# Altium schematic sheet N2K DB2 Interface.SchDoc
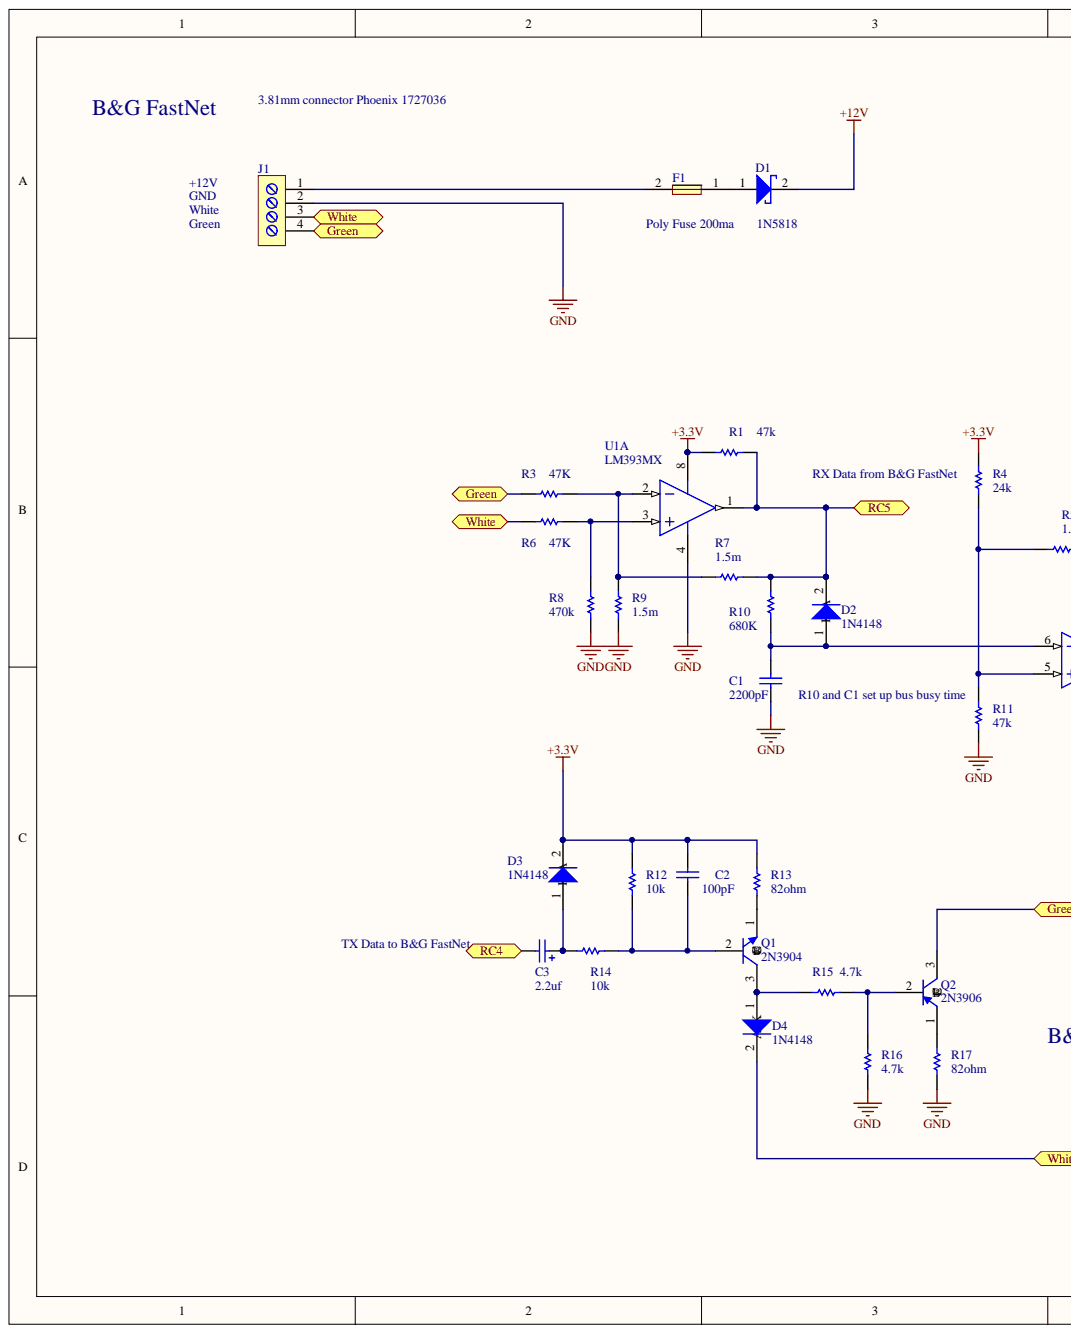
[diagram p0: N2K DB2 Interface.SchDoc, left]
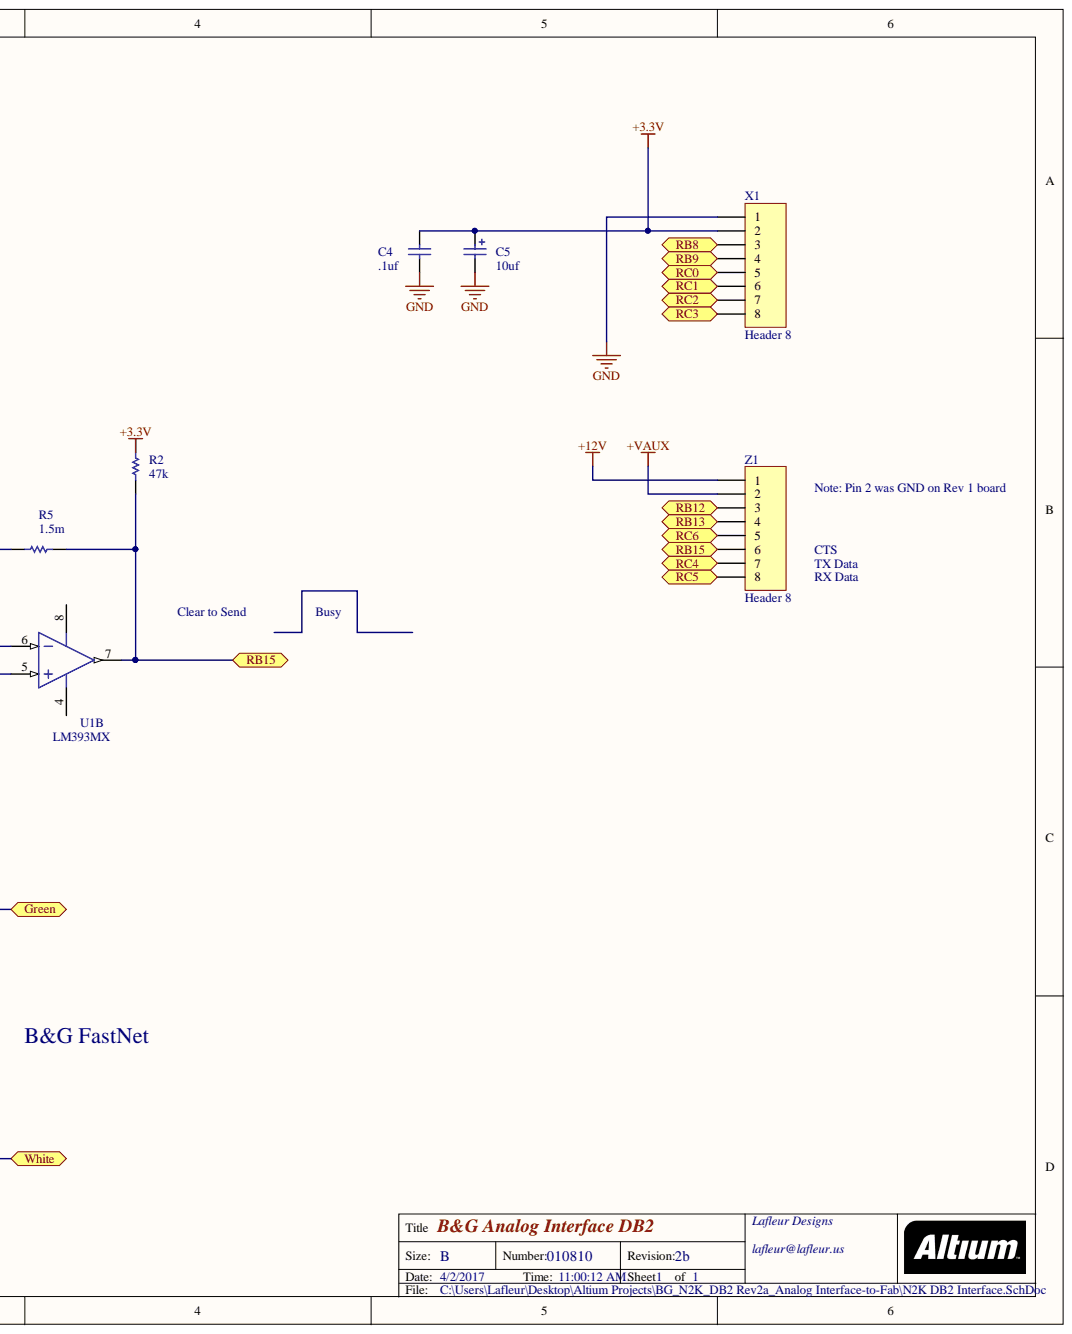
[diagram p1: N2K DB2 Interface.SchDoc, right]

## Parts
C1  value 2200pF  [0805]
C2  value 100pF  [0805]
C3  value 2.2uf  [CAPM3216X18N]
C4  value .1uf  [0805]
C5  value 10uf  [CAPM2528X18N]
D1  1N5818  [DO214]
D2  1N4148  [SOT23-3L]
D3  1N4148  [SOT23-3L]
D4  1N4148  [SOT23-3L]
F1  Poly Fuse 200ma  [PTC 2016L]
J1  (no value)  [TS3.81X4]
Q1  2N3904  [SOT23-3L]
Q2  2N3906  [SOT23-3N]
R1  47k  [6-0805]
R2  47k  [6-0805]
R3  47K  [6-0805]
R4  24k  [6-0805]
R5  1.5m  [6-0805]
R6  47K  [6-0805]
R7  1.5m  [6-0805]
R8  470k  [6-0805]
R9  1.5m  [6-0805]
R10  680K  [6-0805]
R11  47k  [6-0805]
R12  10k  [6-0805]
R13  82ohm  [8-1206]
R14  10k  [6-0805]
R15  4.7k  [6-0805]
R16  4.7k  [6-0805]
R17  82ohm  [8-1206]
U1  LM393MX  [SOIC-SN8_N]  (parts A,B)
X1  Header 8  [HDR1X8]
Z1  Header 8  [HDR1X8]

## Ports
Green, RB8, RB9, RB12, RB13, RB15, RC0, RC1, RC2, RC3, RC4, RC5, RC6, White

## Nets
+3.3V: C2.2 C4.2 C5.1 D3.2 R1.2 R4.2 R12.1 R13.1 U1.8 X1.2
+12V: D1.2 Z1.1
+VAUX: Z1.2
GND: C1.1 C4.1 C5.2 J1.2 R8.1 R9.1 R11.2 R16.2 R17.2 U1.4 X1.1
Green: J1.4 Q2.3 R3.1 ; port Green
RB8: X1.3 ; port RB8
RB9: X1.4 ; port RB9
RB12: Z1.3 ; port RB12
RB13: Z1.4 ; port RB13
RB15: R2.2 R5.1 U1.7 Z1.6 ; port RB15
RC0: X1.5 ; port RC0
RC1: X1.6 ; port RC1
RC2: X1.7 ; port RC2
RC3: X1.8 ; port RC3
RC4: C3.2 Z1.7 ; port RC4
RC5: D2.2 R1.1 R7.1 R10.2 U1.1 Z1.8 ; port RC5
RC6: Z1.5 ; port RC6
White: D4.2 J1.3 R6.1 ; port White
NetC1_2: C1.2 D2.1 R10.1 U1.6
NetC2_1: C2.1 Q1.2 R12.2 R14.2
NetC3_1: C3.1 D3.1 R14.1
NetD1_1: D1.1 F1.1
NetD4_1: D4.1 Q1.3 R15.1
NetF1_2: F1.2 J1.1
NetQ1_1: Q1.1 R13.2
NetQ2_1: Q2.1 R17.1
NetQ2_2: Q2.2 R15.2 R16.1
NetR3_2: R3.2 R7.2 R9.2 U1.2
NetR4_1: R4.1 R5.2 R11.1 U1.5
NetR6_2: R6.2 R8.2 U1.3
N00005:

Not connected: R2 1

## Library data of the parts
C1 C2 C4 = Cap: Capacitor; Component Kind: Standard; LatestRevisionDate: 17-Jul-2002; LatestRevisionNote: Re-released for DXP Platform.; Library Name: Miscellaneous Devices.IntLib; PackageReference: RAD-0.3; Published: 8-Jun-2000; Signal Integrity: Cap; Simulation: CAP; Publisher: Altium Limited
C3 C5 = Cap Pol2: Polarized Capacitor (Axial); Component Kind: Standard; LatestRevisionDate: 17-Jul-2002; LatestRevisionNote: Re-released for DXP Platform.; Library Name: Miscellaneous Devices.IntLib; PackageReference: POLAR0.8; Published: 8-Jun-2000; Publisher: Altium Limited
D1 = SSA34: Diode, Schottky; Component Kind: Standard; DatasheetDocument: RevB, 21-Aug-2003; Library Name: N2K Sensor Board_3.SCHLIB; Published: 5-Jan-2004; Publisher: Altium Limited
D2-D4 = 1N4148: High Conductance Fast Diode; Code_JEDEC: DO-204-AH; Component Kind: Standard; ComponentLink1Description: Manufacturer Link; ComponentLink1URL: http://www.fairchildsemi.com/; ComponentLink2Description: Datasheet; ComponentLink2URL: http://www.fairchildsemi.com/ds/1N%2F1N4148.pdf; DatasheetDocument: 1997; LatestRevisionDate: 17-Jul-2002; LatestRevisionNote: Re-released for DXP Platform.; Library Name: FSC Discrete Diode.IntLib; PackageReference: DO-35; Published: 8-Jun-2000; Signal Integrity: 1N4148; Simulation: 1N4148; Publisher: Altium Limited
F1 = Poly Fuse: Component Kind: Standard; Description: Poly Fuse; Library Name: N2K Sensor Board_3.SCHLIB
J1 = TS4A: Screw Terminal 3.81mm 4X; Component Kind: Standard; Library Name: N2K Sensor Board_3.SCHLIB
Q1 = 2N3904: NPN General Purpose Amplifier; Code_JEDEC: TO-92A; Component Kind: Standard; ComponentLink1Description: Manufacturer Link; ComponentLink1URL: http://www.fairchildsemi.com/; ComponentLink2Description: Datasheet; ComponentLink2URL: http://www.fairchildsemi.com/ds/2N%2F2N3904.pdf; DatasheetVersion: 1997; LatestRevisionDate: 15-Jan-2003; LatestRevisionNote: PCB Footprint 'BCY-W3/D4.7' replaced; Library Name: Miscellaneous Devices.IntLib; PackageReference: TO-92A; PackageVersion: Sep-1998; Published: 8-Jun-2000; Signal Integrity: 2N3904; Simulation: 2N3904; Publisher: Altium Limited
Q2 = 2N3906: PNP General Purpose Amplifier; Code_JEDEC: TO-92A; Component Kind: Standard; ComponentLink1Description: Manufacturer Link; ComponentLink1URL: http://www.fairchildsemi.com/; ComponentLink2Description: Datasheet; ComponentLink2URL: http://www.fairchildsemi.com/ds/2N%2F2N3906.pdf; DatasheetVersion: 1997; LatestRevisionDate: 15-Jan-2003; LatestRevisionNote: PCB Footprint 'BCY-W3/D4.7' replaced; Library Name: Miscellaneous Devices.IntLib; PackageReference: TO-92A; PackageVersion: Sep-1998; Published: 8-Jun-2000; Signal Integrity: 2N3906; Simulation: 2N3906; Publisher: Altium Limited
R1-R17 = ERJ8EN: Precision Thick Film Chip Resistor, 10 Ohm to 2.2M Ohm Range, 1% Tolerance, 1206 Size, 0.125 W; Code_IPC: 3216 [1206]; Component Kind: Standard; ComponentLink1Description: Manufacturer Link; ComponentLink1URL: http://www.panasonic.com/industrial/components/resistive_products.htm; ComponentLink2Description: Datasheet; ComponentLink2URL: http://www.panasonic.com/industrial/components/pdf/AOA0000CE2.pdf; LatestRevisionDate: 19-Mar-2004; LatestRevisionNote: Silkscreen size reduced.; Library Name: Panasonic Resistor.IntLib; PackageDocument: Mar-2003; PackageReference: 8-1206; Published: 17-Dec-2003; Signal Integrity: Res; Simulation: RESISTOR; Publisher: Altium Limited
U1 = LM393MX: Low Power Low Offset Voltage Dual Comparator; Manufacturer: National Semiconductor; PackageTopMarking: NSZXTT*LM*393M; Code_IPC: SOIC127P600-8; Code_JEDEC: MS-012-AA; Component Kind: Standard; ComponentLink1Description: LM393 Datasheet; ComponentLink1URL: http://www.national.com/ds/LM/LM393.pdf; ComponentLink2Description: LM393  Web Page; ComponentLink2URL: http://www.national.com/pf/LM/LM393.html; ComponentLink3Description: M08A Package Page; ComponentLink3URL: http://www.national.com/packaging/folders/m08a.html; CompType: Comparator; DatasheetVersion: Aug-2002; DigiKeyPartNumber: LM393MXCT-ND; Library Name: NSC Comparator.IntLib; PackageDescription: SOIC Narrow, 4.9 x 3.9 x 1.45mm, 8 Lead, 1.27mm Pitch; PackageReference: M08A; PackageVersion: revL, Sep-2006; PartNumber: LM393MX; PinCount: 8; Published: 4-Dec-2008; RoHS: O; Publisher: Altium Limited
X1 Z1 = Header 8: Header, 8-Pin; Component Kind: Standard; LatestRevisionDate: 17-Jul-2002; LatestRevisionNote: Re-released for DXP Platform.; Library Name: Miscellaneous Connectors.IntLib; Signal Integrity: Connector; Publisher: Altium Limited
